# Altium schematic sheet Breakout_Connectivities.SchDoc(Breakout_Connectivities)
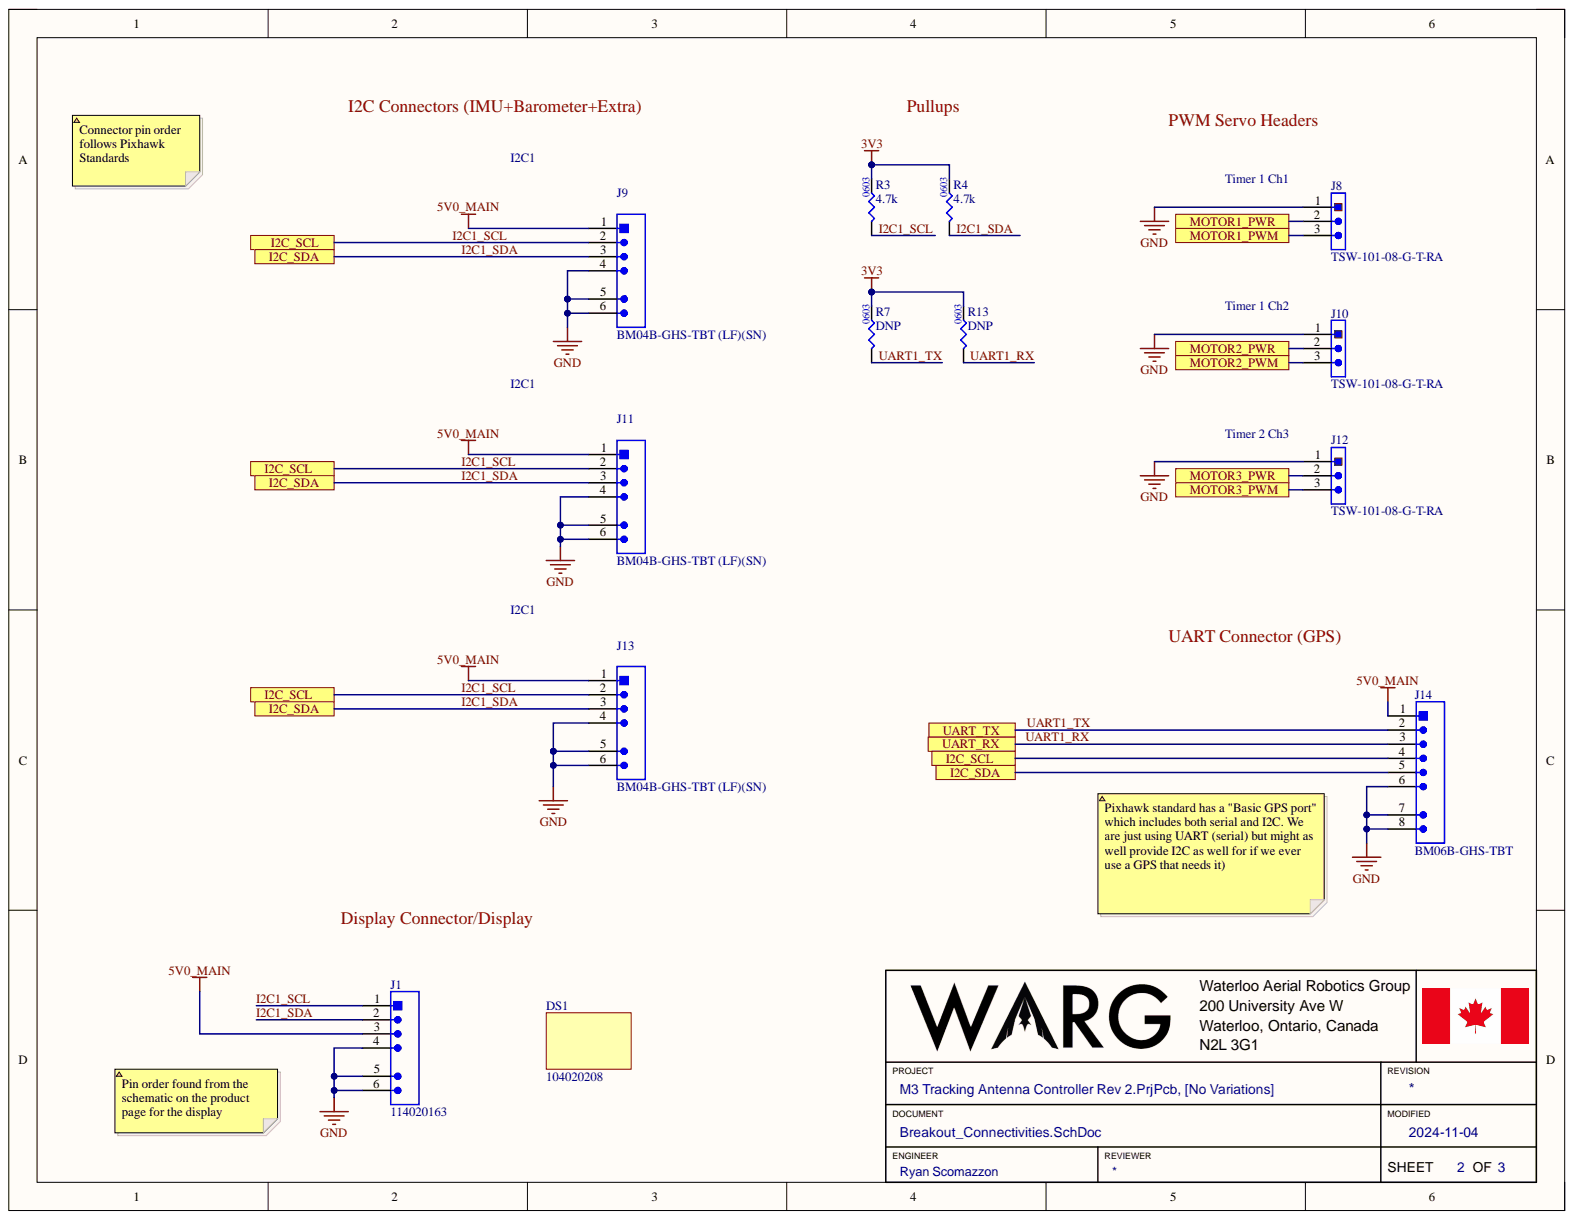
[diagram p0: Breakout_Connectivities.SchDoc]

## Parts
DS1  104020208  [104020208-Footprint-1]
J1  114020163  [114020163-Footprint-1]
J8  TSW-101-08-G-T-RA  [TSW-101-08-T-T-RA_SAI]
J9  BM04B-GHS-TBT (LF)(SN)  [CONN_BM04B-GHS-TBT_JST]
J10  TSW-101-08-G-T-RA  [TSW-101-08-T-T-RA_SAI]
J11  BM04B-GHS-TBT (LF)(SN)  [CONN_BM04B-GHS-TBT_JST]
J12  TSW-101-08-G-T-RA  [TSW-101-08-T-T-RA_SAI]
J13  BM04B-GHS-TBT (LF)(SN)  [CONN_BM04B-GHS-TBT_JST]
J14  BM06B-GHS-TBT  [BM06B-GHS-TBT-Footprint-1]
R3  value 4.7k  Case/Package: 0603  Resistance: 4.7k  [.RES_0603-Footprint-1]  (hidden comment: RC0603JR-074K7L)
R4  value 4.7k  Case/Package: 0603  Resistance: 4.7k  [.RES_0603-Footprint-1]  (hidden comment: RC0603JR-074K7L)
R7  value DNP  [.RES_0603-Footprint-1]  (hidden comment: .RES_0603_DNP)
R13  value DNP  [.RES_0603-Footprint-1]  (hidden comment: .RES_0603_DNP)

## Ports
I2C_SCL, I2C_SDA, MOTOR1_PWM, MOTOR1_PWR, MOTOR2_PWM, MOTOR2_PWR, MOTOR3_PWM, MOTOR3_PWR, UART_RX, UART_TX

## Nets
3V3: R3.2 R4.2 R7.2 R13.2
5V0_MAIN: J1.3 J9.1 J11.1 J13.1 J14.1
GND: J1.4 J1.5 J1.6 J8.1 J9.4 J9.5 J9.6 J10.1 J11.4 J11.5 J11.6 J12.1 J13.4 J13.5 J13.6 J14.6 J14.7 J14.8
I2C1_SCL: J1.1 J9.2 J11.2 J13.2 J14.4 R3.1 ; port I2C_SCL
I2C1_SDA: J1.2 J9.3 J11.3 J13.3 J14.5 R4.1 ; port I2C_SDA
MOTOR1_PWM: J8.3 ; port MOTOR1_PWM
MOTOR1_PWR: J8.2 ; port MOTOR1_PWR
MOTOR2_PWM: J10.3 ; port MOTOR2_PWM
MOTOR2_PWR: J10.2 ; port MOTOR2_PWR
MOTOR3_PWM: J12.3 ; port MOTOR3_PWM
MOTOR3_PWR: J12.2 ; port MOTOR3_PWR
UART1_RX: J14.3 R13.1 ; port UART_RX
UART1_TX: J14.2 R7.1 ; port UART_TX

## Library data of the parts
DS1 = CMP-00026-00000-1: Grove - OLED Display 0.96" (SSD1315); Component Kind: Standard; ComponentLink1Description: Documentation links; ComponentLink1URL: https://www.seeedstudio.com/Grove-OLED-Display-0-96-SSD1315-p-4294.html; Library Name: WARG
J1 = CMP-002-00018-7: GROVE FEMALE HEADER 20PACK; NOTE: 20 PACK, DO NOT ORDER THIS FOR EACH CONNECTOR; Component Kind: Standard; ComponentLink1Description: Design Resources (datasheet sucks); ComponentLink1URL: https://www.seeedstudio.com/blog/2022/11/18/seeed-grove-designers-guide-pcb-design-guidelines-and-more/; Pins: 4; Technology: Surface Mount; Library Name: WARG
J8 J10 J12 = CMP-070-000000-5: TSW-101-09-G-T-RA Connector Header Through Hole, Right Angle 3 position; Mfr_Name: Samtec Inc; Component Kind: Standard; Design Item ID: TSW-101-08-G-T-RA; RefDes: RefDes; Source: TSW-101-08-G-T-RA.SchLib; Type: Type; Library Name: WARG
J9 J11 J13 = CMP-082-000000-8: CONN HEADER SMD 4POS 1.25MM; Component Kind: Standard; Mount: Vertical; Pins: 4; Type: JST-GH; Library Name: WARG
J14 = CMP-002-00014-10: Connector Header Surface Mount 6 position 0.049" (1.25mm); Entry Type: Vert; Component Kind: Standard; Pins: 6; Series: JST-GH; Library Name: WARG
R3 R4 = CMP-009-00019-3: RES 4.7K OHM 5% 1/10W 0603; Power Rating: 100mW; Voltage Rating (DC): 50V; Component Kind: Standard; Resistance Tolerance: 5%; Library Name: WARG
R7 R13 = CMP-009-00018-3: DNP 0603 Resistor; Component Kind: Standard; Library Name: WARG
